# Altium schematic sheet power.SchDoc(power)
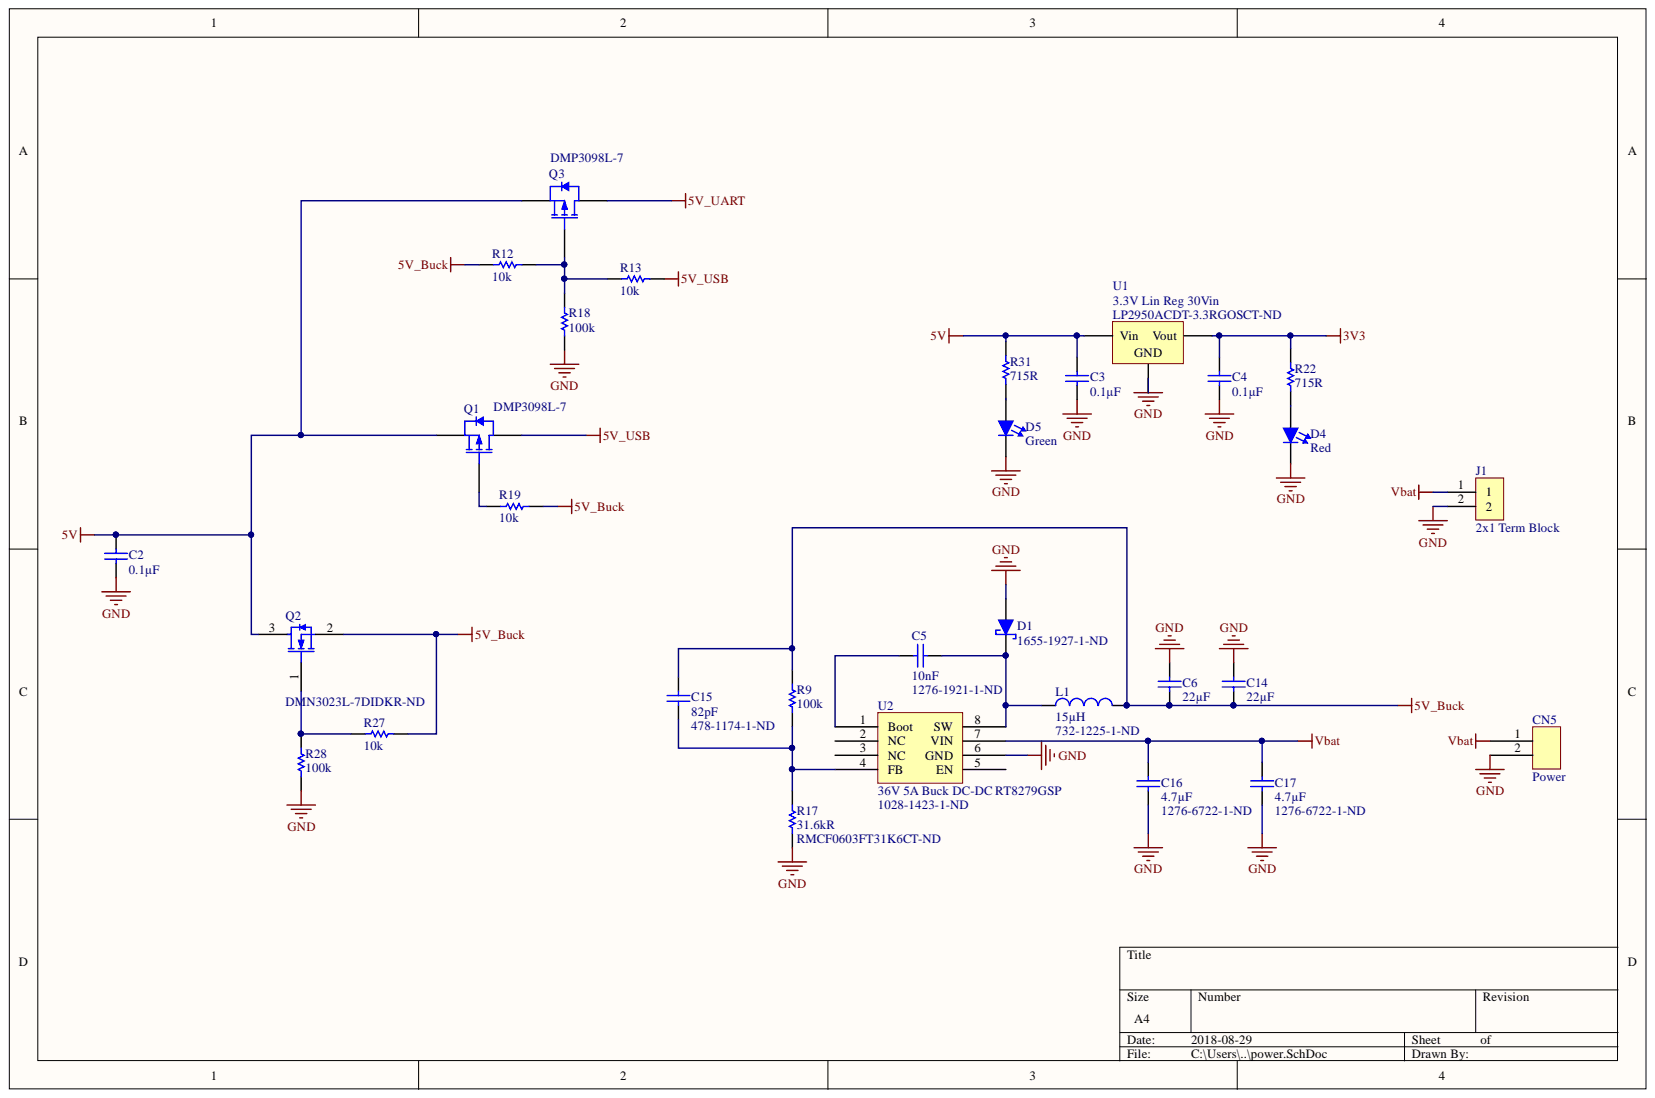
[diagram p0: power.SchDoc]

## Parts
C2  0.1μF  [CAPC1608N(0603")]
C3  0.1μF  [CAPC1608N(0603")]
C4  0.1μF  [CAPC1608N(0603")]
C5  10nF  Supplier Part Number 1: 1276-1921-1-ND  [CAPC1608N(0603")]
C6  22µF  [CAPC2012N(0805")]
C14  22µF  [CAPC2012N(0805")]
C15  82pF  Supplier Part Number 1: 478-1174-1-ND  [CAPC1608N(0603")]
C16  4.7µF  Supplier Part Number 1: 1276-6722-1-ND  [CAPC2012N(0805")]
C17  4.7µF  Supplier Part Number 1: 1276-6722-1-ND  [CAPC2012N(0805")]
CN5  Power  [2X1 Power Connector 90 degrees]
D1  Supplier Part Number 1: 1655-1927-1-ND  [SOD-123F]  (hidden comment: DSS14UTR)
D4  Red  [LED0805]
D5  Green  [LED0805]
J1  2x1 Term Block  [2X1 Terminal Blocks]
L1  15µH  Supplier Part Number 1: 732-1225-1-ND  [WE-PD-XL]
Q1  DMP3098L-7  [DIOD-SOT23-3_V]
Q2  Supplier Part Number 1: DMN3023L-7DIDKR-ND  [SOT-23]  (hidden comment: MOSFET N-Channel, 30V, 6.2A,)
Q3  DMP3098L-7  [DIOD-SOT23-3_V]
R9  100k  [RESC1608N(0603")]
R12  10k  [RESC1608N(0603")]
R13  10k  [RESC1608N(0603")]
R17  31.6kR  Supplier Part Number 1: RMCF0603FT31K6CT-ND  [RESC1608N(0603")]
R18  100k  [RESC1608N(0603")]
R19  10k  [RESC1608N(0603")]
R22  715R  [RESC1608N(0603")]
R27  10k  [RESC1608N(0603")]
R28  100k  [RESC1608N(0603")]
R31  715R  [RESC1608N(0603")]
U1  3.3V Lin Reg 30Vin  Supplier Part Number 1: LP2950ACDT-3.3RGOSCT-ND  [T0-252]
U2  36V 5A Buck DC-DC RT8279GSP  Supplier Part Number 3: 1028-1423-1-ND  [8-SOP-EP]

## Nets
3V3: C4.2 R22.2 U1.3
5V: C2.2 C3.2 Q1.2 Q2.3 Q3.2 R31.2 U1.2
5V_Buck: C6.1 C14.1 C15.2 L1.2 Q2.2 R9.2 R12.1 R19.2 R27.2
5V_UART: Q3.3
5V_USB: Q1.3 R13.2
GND: C2.1 C3.1 C4.1 C6.2 C14.2 C16.2 C17.2 CN5.2 D1.A D4.1 D5.1 J1.2 R17.1 R18.1 R28.1 U1.1 U2.6(GND)
Vbat: C16.1 C17.1 CN5.1 J1.1 U2.7(VIN)
NetC5_1: C5.1 D1.K L1.1 U2.8(SW)
NetC5_2: C5.2 U2.1(Boot)
NetC15_1: C15.1 R9.1 R17.2 U2.4(FB)
NetD4_2: D4.2 R22.1
NetD5_2: D5.2 R31.1
NetQ1_1: Q1.1 R19.1
NetQ2_1: Q2.1 R27.1 R28.2
NetQ3_1: Q3.1 R12.2 R13.1 R18.2

Not connected: U2 2(NC) 3(NC) 5(EN)

## Library data of the parts
C2-C4 = 0.1uF 100V 0603 SMD, Ceramic: Capacitor, Ceramic, 100V, +/- 10%, 0603 SMD, X7R; MFG#: CGA3E3X7S2A104K080AB; MFG: TDK; Value: 0.1μF; Supplier Part Number 1: 445-6938-1-ND; Supplier 1: Digi-Key; Published: 16-04-26; PackageDescription: Chip Capacitor; Component Kind: Standard; Publisher: AUVic; Library Name: AUVic_Integrated_Library_Capacitors.IntLib; Created By: Ashwin Sira
C5 = 10 nF, 50V 0603 SMD, Ceramic: Capacitor, Ceramic, 50V, +/- 10%, 0603 SMD; MFG#: GRM188R61A475KE15D; MFG: Samsung; Value: 10nF; Supplier 1: Digi-Key; Published: 16-06-23; PackageDescription: Chip Capacitor; Component Kind: Standard; Publisher: AUVic; Library Name: AUVic_Integrated_Library_Capacitors.IntLib; Created By: Gabriel
C6 C14 = 22uF 35V 0805 SMD, Ceramic: Capacitor, Ceramic, 35V, +/- 20%, 0805 SMD, X5R; Value: 22µF; Supplier Part Number 1: 445-14428-1-ND; Supplier 1: Digi-Key; Published: 14-06-01; PackageDescription: Chip Capacitor; Component Kind: Standard; Publisher: AUVic; Library Name: AUVic_Integrated_Library_Capacitors.IntLib
C15 = 82pF 50V 0603 SMD, Ceramic: 82pF 50V Ceramic Capacitor C0G, NP0 0603; Value: 82pF; Supplier 1: Digi-Key; Created On: 2018-07-18; ComponentLink1URL: https://www.digikey.ca/product-detail/en/avx-corporation/06035A820JAT2A/478-1174-1-ND/564206; ComponentLink1Description: Digikey; Component Kind: Standard; Library Name: AUVic_Integrated_Library_Capacitors.IntLib; Created By: Robert Keen
C16 C17 = 4.7uF 35V 0805 SMD, Ceramic: Capacitor, Ceramic, 35V, +/- 10%, 0805 SMD, X5R; Made By: Andy Bates; Value: 4.7µF; Supplier 1: Digi-Key; Published: 16-11-12; PackageDescription: Chip Capacitor; Component Kind: Standard; Publisher: AUVic; Library Name: AUVic_Integrated_Library_Capacitors.IntLib
CN5 = 2x1, Male header, Right Angle, Latch holder: Connector Header Through Hole, Right Angle 2 position 0.312" (7.92mm); Made by: Robert Keen; Supplier Part Number 3: H2788CT-ND; Supplier Part Number 2: H1615-ND; Supplier Part Number 1: H10706-ND; Supplier 3: Digi-Key; Supplier 2: Digi-Key; Supplier 1: Digi-Key; Made on: 2018-08-16; ComponentLink3URL: https://www.digikey.ca/product-detail/en/hirose-electric-co-ltd/DF22-1416SCF/H2788CT-ND/365031; ComponentLink3Description: Digikey (crimp); ComponentLink2URL: https://www.digikey.ca/product-detail/en/hirose-electric-co-ltd/DF22R-2S-7.92C-28/H1615-ND/510248; ComponentLink2Description: Digikey (female); ComponentLink1URL: https://www.digikey.ca/product-detail/en/hirose-electric-co-ltd/DF22R-2P-7.92DS-05/H10706-ND/1025057; ComponentLink1Description: Digikey (male); Component Kind: Standard; Library Name: AUVic_Integrated_Library_Connectors.IntLib
D1 = DSS14UTR: Diode, Schottky, 40V, 1A; Supplier 1: Digi-Key; Date Created: 2018-07-11; ComponentLink1URL: https://www.digikey.ca/product-detail/en/smc-diode-solutions/DSS14UTR/1655-1927-1-ND/8341869; ComponentLink1Description: Digikey; Component Kind: Standard; Publisher: AUVic; Library Name: AUVic_Integrated_Library_Diodes.IntLib; Created By: Robert Keen
D4 = LED, 0805 (2012), Red, Clear: Supplier Part Number 1: 732-4984-1-ND; Supplier 1: Digi-Key; Description: LED, 0805 (2012), Red, Clear; Component Kind: Standard; Colour: Red; Library Name: AUVic_Integrated_Library_Diodes.IntLib; Created By: Ashwin Sira
D5 = LED, 0805 (2012), Green, Clear: Supplier Part Number 1: 475-1410-1-ND; Supplier 1: Digi-Key; Description: LED, 0805 (2012), Green, Clear; Component Kind: Standard; Colour: Green; Library Name: AUVic_Integrated_Library_Diodes.IntLib; Created By: Andy Bates
J1 = 2x1 Terminal Block: Made by: Andy Bates; Supplier Part Number 1: ED2740-ND; Supplier 1: Digikey; Component Kind: Standard; Library Name: AUVic_Integrated_Library_Connectors.IntLib
L1 = 15µH 5A Inductor: 15µH Shielded Wirewound Inductor 5A 27 mOhm Max Nonstandard; Value: 15µH; Supplier 1: Digi-Key; Created On: 2018-06-13; ComponentLink1URL: https://www.digikey.ca/product-detail/en/wurth-electronics-inc/744770115/732-1225-1-ND/1639286; ComponentLink1Description: Digikey; Component Kind: Standard; Library Name: AUVic_Integrated_Library_Inductors.IntLib; Created By: Robert Keen
Q1 Q3 = CMP-0697-00001-2: P-Channel Enhancement Mode MOSFET, -30 V VDS, -55 to 155 degC, 3-Pin SOT23, RoHS, Tape and Reel; Manufacturer: Diodes Inc; VGS(th) (Max.): -2.1 V; VGS: +/- 20 V; VDS: -30 V; RDS(on) (Max.) (VGS: 4.5 V)=120 mOhm; PD (TA: 25 degC)=1.08 W; IDS (TA: 25 degC)=-3.8 A; RoHS: TRUE; PartNumber: DMP3098L-7; Packing: Tape and Reel; PackageVersion: Rev. 75; PackageReference: SOT23; PackageDescription: 3-Pin Small Outline Transistor, Body 1.3 x 2.9 mm, Pitch 0.95 mm; Operating Temperature Range: -55 to 150 degC; Mounting Technology: Surface Mount; Library Name: Altium Content Vault; DatasheetVersion: Rev. 8 - 2; ComponentLink3URL: http://www.diodes.com/datasheets/ap02002.pdf; ComponentLink3Description: Package Specification; ComponentLink2URL: http://www.diodes.com/datasheets/ds31447.pdf; ComponentLink2Description: Datasheet; ComponentLink1URL: http://www.diodes.com/; ComponentLink1Description: Manufacturer URL; Component Kind: Standard
Q2 = MOSFET N-Channel, 30V, 6.2A,: N-Channel 30V 6.2A (Ta) 900mW (Ta) Surface Mount SOT-23; Supplier 1: Digi-Key; ComponentLink1URL: https://www.digikey.ca/product-detail/en/diodes-incorporated/DMN3023L-7/DMN3023L-7DICT-ND/5453155; ComponentLink1Description: Digi-Key; Component Kind: Standard; Library Name: AUVic_Integrated_Library_Transistors.IntLib; Created By: Poorna Chander Kalidas
R9 R18 R28 = 100kR, 1%, 1/10W, 0603 SMD: Owned By: AUVic; Made By: Andy Bates; Value: 100k; Supplier Part Number 1: 311-100KGRCT-ND; Supplier 1: Digi-Key; Library Name: AUVic_Integrated_Library_Resistors.IntLib; Last Edited: 14-06-30; Description: 100kR, 1%, 1/10W, 0603 SMD; Created On: 14-06-30; Component Kind: Standard
R12 R13 R19 R27 = 10kR, 1%, 1/10W, 0603 SMD: Owned By: AUVic; Made By: Ashwin Sira; Value: 10k; Supplier Part Number 1: 311-10.0KHRCT-ND; Supplier 1: Digi-Key; Library Name: AUVic_Integrated_Library_Resistors.IntLib; Last Edited: 14-05-31; Description: 10kR, 1%, 1/10W, 0603 SMD; Created On: 14-05-31; Component Kind: Standard
R17 = 31.6kR, 1%, 1/10W, 0603, SMD: Value: 31.6kR; Supplier 1: Digi-Key; Description: 31.6kR, 1%, 1/10W, 0603, SMD; Date Created: 2018-07-18; ComponentLink1URL: https://www.digikey.ca/product-detail/en/stackpole-electronics-inc/RMCF0603FT31K6/RMCF0603FT31K6CT-ND/1943089; ComponentLink1Description: Digikey; Component Kind: Standard; Library Name: AUVic_Integrated_Library_Resistors.IntLib; Created By: Robert Keen
R22 R31 = 715R, 1%, 1/10W, 0603, SMD: Value: 715R; Supplier Part Number 1: 541-715HCT-ND; Supplier 1: Digi-Key; Description: 715R, 1%, 1/10W, 0603, SMD; Date Created: 2016-06-10; Component Kind: Standard; Publisher: AUVic; Library Name: AUVic_Integrated_Library_Resistors.IntLib; Created By: Ashwin Sira
U1 = 3.3V TO-252 Linear Regulator: Supplier 1: Digi-Key; Component Kind: Standard; Library Name: AUVic_Integrated_Library_Regulators.IntLib
U2 = 36V 5A Buck DC-DC RT8279GSP: Made by: Robert Keen; Supplier 3: Digi-Key; Supplier 2: Digi-Key; Supplier 1: Digi-Key; Made on: 2017-07-18; ComponentLink1URL: https://www.digikey.ca/product-detail/en/richtek-usa-inc/RT8279GSP/1028-1423-1-ND/5880353; ComponentLink1Description: Digikey; Component Kind: Standard; Library Name: AUVic_Integrated_Library_Regulators.IntLib
